# Altium schematic sheet Sheet1.SchDoc
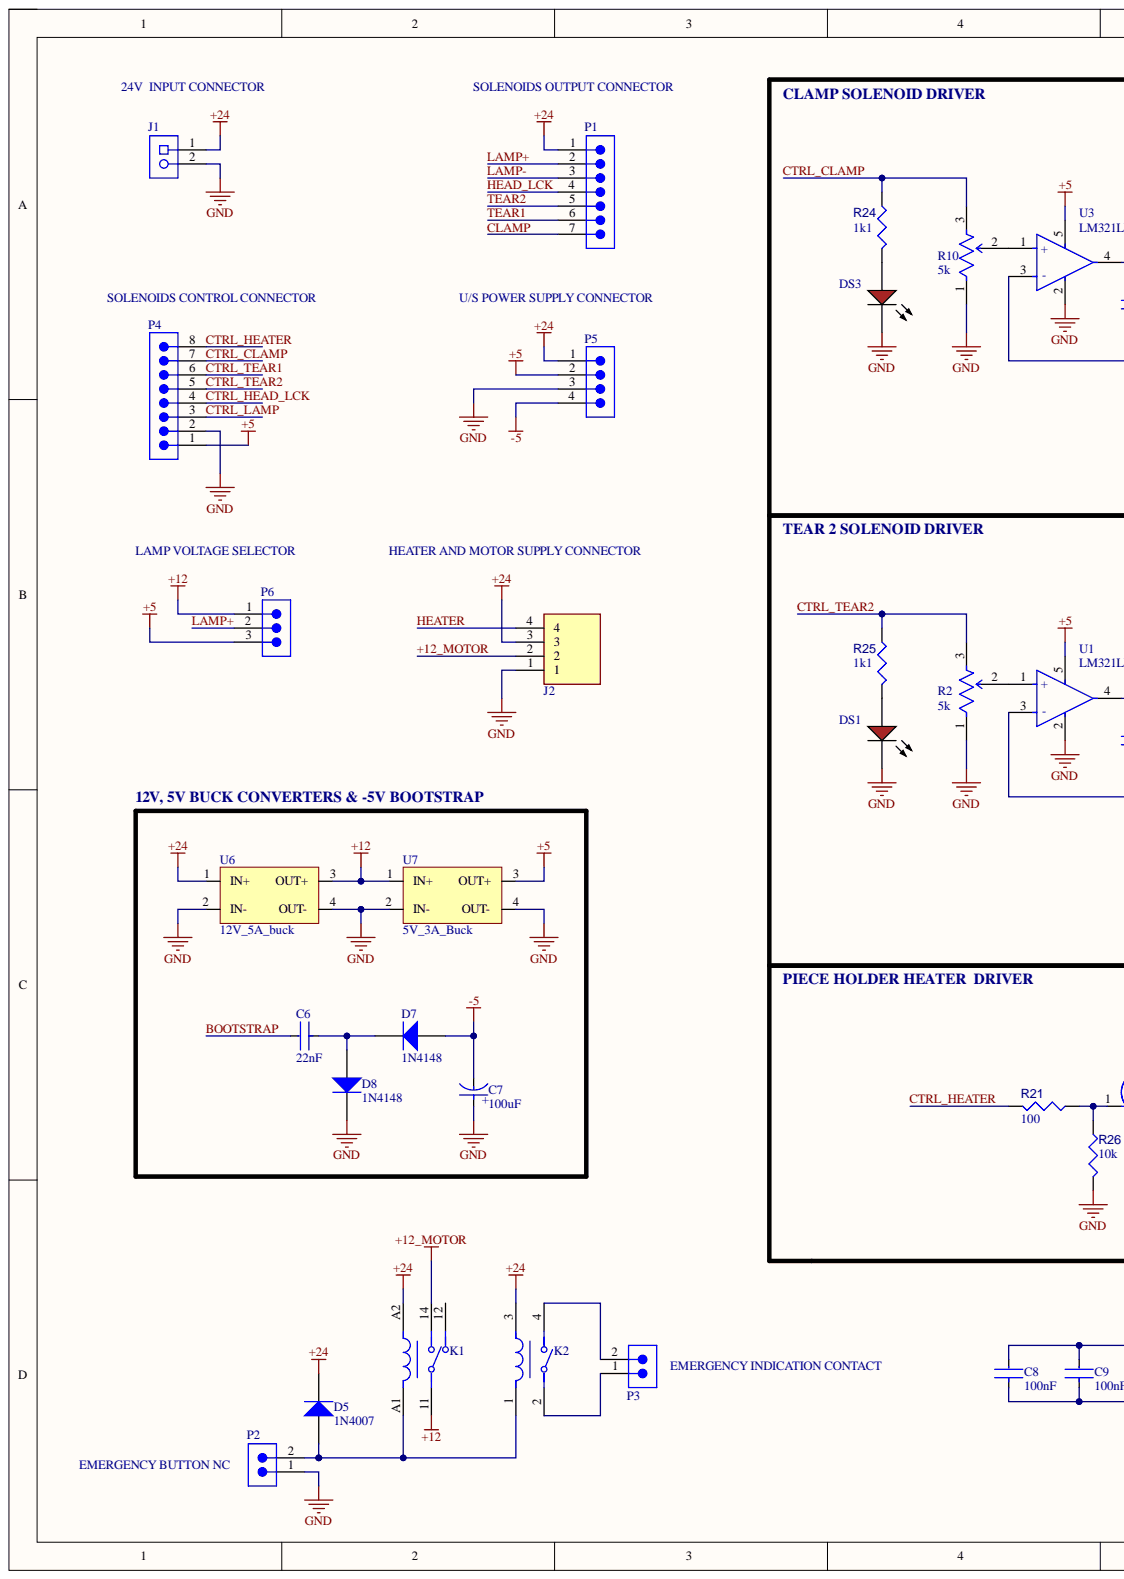
[diagram p0: Sheet1.SchDoc, left]
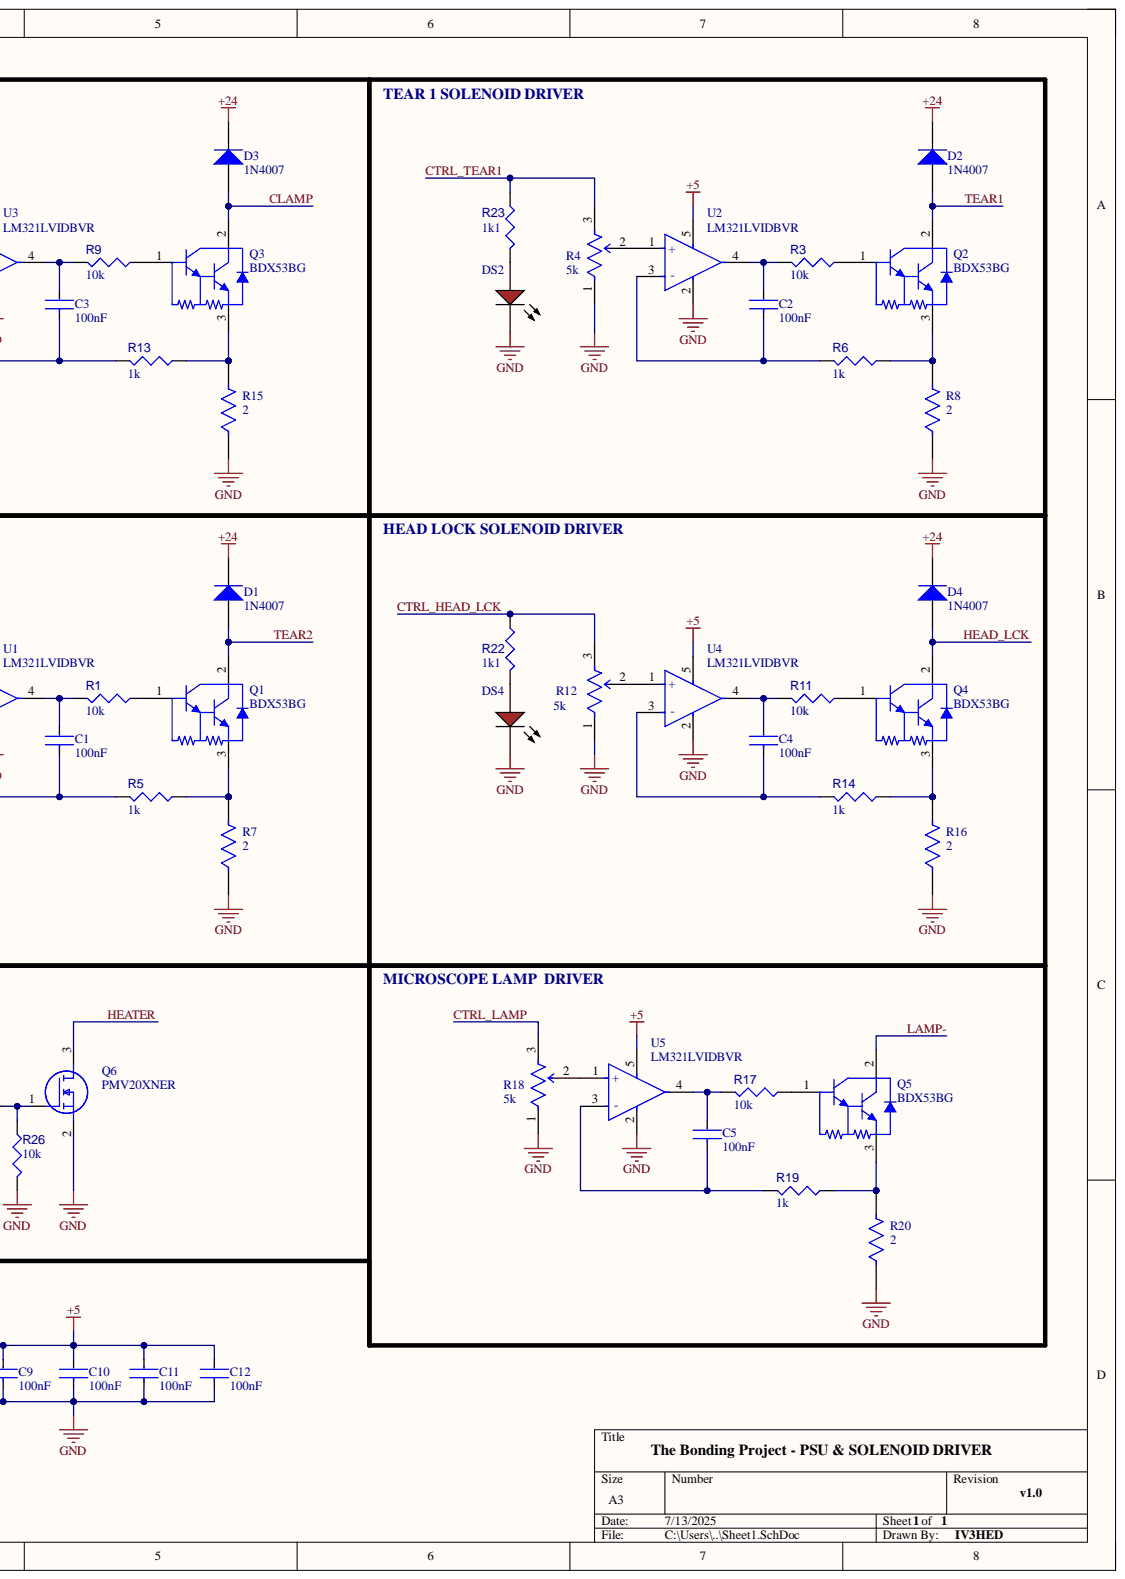
[diagram p1: Sheet1.SchDoc, right]

## Parts
C1  Capacitance: 100nF  [FP-0805-L_2_01_0_2-W_1_25-MFG]  (hidden comment: 08055C104K4T2A)
C2  Capacitance: 100nF  [FP-0805-L_2_01_0_2-W_1_25-MFG]  (hidden comment: 08055C104K4T2A)
C3  Capacitance: 100nF  [FP-0805-L_2_01_0_2-W_1_25-MFG]  (hidden comment: 08055C104K4T2A)
C4  Capacitance: 100nF  [FP-0805-L_2_01_0_2-W_1_25-MFG]  (hidden comment: 08055C104K4T2A)
C5  Capacitance: 100nF  [FP-0805-L_2_01_0_2-W_1_25-MFG]  (hidden comment: 08055C104K4T2A)
C6  Capacitance: 22nF  [FP-BFC247066332-MFG]  (hidden comment: BFC247066332)
C7  Capacitance: 100uF  [FP-RAD-TH-D_6_3_0_5-L_7_1-MFG]  (hidden comment: EEAGA1A470H)
C8  Capacitance: 100nF  [FP-0805-L_2_01_0_2-W_1_25-MFG]  (hidden comment: 08055C104K4T2A)
C9  Capacitance: 100nF  [FP-0805-L_2_01_0_2-W_1_25-MFG]  (hidden comment: 08055C104K4T2A)
C10  Capacitance: 100nF  [FP-0805-L_2_01_0_2-W_1_25-MFG]  (hidden comment: 08055C104K4T2A)
C11  Capacitance: 100nF  [FP-0805-L_2_01_0_2-W_1_25-MFG]  (hidden comment: 08055C104K4T2A)
C12  Capacitance: 100nF  [FP-0805-L_2_01_0_2-W_1_25-MFG]  (hidden comment: 08055C104K4T2A)
D1  1N4007  [NXP-SOD27-2_V]
D2  1N4007  [NXP-SOD27-2_V]
D3  1N4007  [NXP-SOD27-2_V]
D4  1N4007  [NXP-SOD27-2_V]
D5  1N4007  [NXP-SOD27-2_V]
D7  1N4148  [NXP-SOD27-2_V]
D8  1N4148  [NXP-SOD27-2_V]
DS1  LTL-1CHE  [INDPR60-254-520X380X650]  (value not drawn)
DS2  LTL-1CHE  [INDPR60-254-520X380X650]  (value not drawn)
DS3  LTL-1CHE  [INDPR60-254-520X380X650]  (value not drawn)
DS4  LTL-1CHE  [INDPR60-254-520X380X650]  (value not drawn)
J1  1729128  [PHOE-1729128-2_V]  (value not drawn)
J2  1711042  [1711042]  (value not drawn)
K1  RP011024  [FP-4-1393224-6-MFG]  (value not drawn)
K2  FEME_RST1-24  [FP-OMR-C-112H_V000-MFG]  (value not drawn)
P1  61300711121  [61300711121]  (value not drawn)
P2  61300211121  [61300211121]  (value not drawn)
P3  61300211121  [61300211121]  (value not drawn)
P4  61300811121  [61300811121]  (value not drawn)
P5  61300411121  [61300411121]  (value not drawn)
P6  61300311121  [61300311121]  (value not drawn)
Q1  BDX53BG  [ONSC-TO-220-3-221A-09]
Q2  BDX53BG  [ONSC-TO-220-3-221A-09]
Q3  BDX53BG  [ONSC-TO-220-3-221A-09]
Q4  BDX53BG  [ONSC-TO-220-3-221A-09]
Q5  BDX53BG  [ONSC-TO-220-3-221A-09]
Q6  PMV20XNER  [SOT95P230X110-3N]
R1  value 10k  [RESC0805(2012)_L]  (hidden comment: Resistor 1K +/-5% 0805 125 mW)
R2  Resistance: 5k  [FP-3296W-MFG]  (hidden comment: 3296W-1-103LF)
R3  value 10k  [RESC0805(2012)_L]  (hidden comment: Resistor 1K +/-5% 0805 125 mW)
R4  Resistance: 5k  [FP-3296W-MFG]  (hidden comment: 3296W-1-103LF)
R5  value 1k  [RESC0805(2012)_L]  (hidden comment: Resistor 1K +/-5% 0805 125 mW)
R6  value 1k  [RESC0805(2012)_L]  (hidden comment: Resistor 1K +/-5% 0805 125 mW)
R7  value 2  [FP-RL2512-MFG]  (hidden comment: CMP-03412-013102-1)
R8  value 2  [FP-RL2512-MFG]  (hidden comment: CMP-03412-013102-1)
R9  value 10k  [RESC0805(2012)_L]  (hidden comment: Resistor 1K +/-5% 0805 125 mW)
R10  Resistance: 5k  [FP-3296W-MFG]  (hidden comment: 3296W-1-103LF)
R11  value 10k  [RESC0805(2012)_L]  (hidden comment: Resistor 1K +/-5% 0805 125 mW)
R12  Resistance: 5k  [FP-3296W-MFG]  (hidden comment: 3296W-1-103LF)
R13  value 1k  [RESC0805(2012)_L]  (hidden comment: Resistor 1K +/-5% 0805 125 mW)
R14  value 1k  [RESC0805(2012)_L]  (hidden comment: Resistor 1K +/-5% 0805 125 mW)
R15  value 2  [FP-RL2512-MFG]  (hidden comment: CMP-03412-013102-1)
R16  value 2  [FP-RL2512-MFG]  (hidden comment: CMP-03412-013102-1)
R17  value 10k  [RESC0805(2012)_L]  (hidden comment: Resistor 1K +/-5% 0805 125 mW)
R18  Resistance: 5k  [FP-3296W-MFG]  (hidden comment: 3296W-1-103LF)
R19  value 1k  [RESC0805(2012)_L]  (hidden comment: Resistor 1K +/-5% 0805 125 mW)
R20  value 2  [FP-RL2512-MFG]  (hidden comment: CMP-03412-013102-1)
R21  value 100  [RESC0805(2012)_L]  (hidden comment: Resistor 1K +/-5% 0805 125 mW)
R22  value 1k1  [RESC0805(2012)_L]  (hidden comment: Resistor 1K +/-5% 0805 125 mW)
R23  value 1k1  [RESC0805(2012)_L]  (hidden comment: Resistor 1K +/-5% 0805 125 mW)
R24  value 1k1  [RESC0805(2012)_L]  (hidden comment: Resistor 1K +/-5% 0805 125 mW)
R25  value 1k1  [RESC0805(2012)_L]  (hidden comment: Resistor 1K +/-5% 0805 125 mW)
R26  value 10k  [RESC0805(2012)_L]  (hidden comment: Resistor 1K +/-5% 0805 125 mW)
U1  LM321LVIDBVR  [FP-LM321LVIDBVR-MFG]
U2  LM321LVIDBVR  [FP-LM321LVIDBVR-MFG]
U3  LM321LVIDBVR  [FP-LM321LVIDBVR-MFG]
U4  LM321LVIDBVR  [FP-LM321LVIDBVR-MFG]
U5  LM321LVIDBVR  [FP-LM321LVIDBVR-MFG]
U6  12V_5A_buck  [12V_5A_buck-Footprint-1]
U7  5V_3A_Buck  [New footprint 1]

## Nets
+5: C8.2 C9.2 C10.2 C11.2 C12.2 P4.1 P5.2 P6.3 U1.5 U2.5 U3.5 U4.5 U5.5 U7.3(OUT+)
+12: K1.11 P6.1 U6.3(OUT+) U7.1(IN+)
+24: D1.2 D2.2 D3.2 D4.2 D5.2 J1.1 J2.3 K1.A2 K2.3 P1.1 P5.1 U6.1(IN+)
-5: C7.1 D7.1 P5.4
GND: C7.2 C8.1 C9.1 C10.1 C11.1 C12.1 D8.2 DS1.2 DS2.2 DS3.2 DS4.2 J1.2 J2.1 P2.1 P4.2 P5.3 Q6.2 R2.1 R4.1 R7.1 R8.1 R10.1 R12.1 R15.1 R16.1 R18.1 R20.1 R26.2 U1.2 U2.2 U3.2 U4.2 U5.2 U6.2(IN-) U6.4(OUT-) U7.2(IN-) U7.4(OUT-)
+12_MOTOR: J2.2 K1.14
BOOTSTRAP: C6.2
CLAMP: D3.1 P1.7 Q3.2
CTRL_CLAMP: P4.7 R10.3 R24.1
CTRL_HEAD_LCK: P4.4 R12.3 R22.1
CTRL_HEATER: P4.8 R21.2
CTRL_LAMP: P4.3 R18.3
CTRL_TEAR1: P4.6 R4.3 R23.1
CTRL_TEAR2: P4.5 R2.3 R25.1
HEAD_LCK: D4.1 P1.4 Q4.2
HEATER: J2.4 Q6.3
LAMP+: P1.2 P6.2
LAMP-: P1.3 Q5.2
TEAR1: D2.1 P1.6 Q2.2
TEAR2: D1.1 P1.5 Q1.2
NetC1_1: C1.1 R5.2 U1.3(-)
NetC1_2: C1.2 R1.2 U1.4
NetC2_1: C2.1 R6.2 U2.3(-)
NetC2_2: C2.2 R3.2 U2.4
NetC3_1: C3.1 R13.2 U3.3(-)
NetC3_2: C3.2 R9.2 U3.4
NetC4_1: C4.1 R14.2 U4.3(-)
NetC4_2: C4.2 R11.2 U4.4
NetC5_1: C5.1 R19.2 U5.3(-)
NetC5_2: C5.2 R17.2 U5.4
NetC6_1: C6.1 D7.2 D8.1
NetD5_1: D5.1 K1.A1 K2.1 P2.2
NetDS1_1: DS1.1 R25.2
NetDS2_1: DS2.1 R23.2
NetDS3_1: DS3.1 R24.2
NetDS4_1: DS4.1 R22.2
NetK2_2: K2.2 P3.1
NetK2_4: K2.4 P3.2
NetQ1_1: Q1.1 R1.1
NetQ1_3: Q1.3 R5.1 R7.2
NetQ2_1: Q2.1 R3.1
NetQ2_3: Q2.3 R6.1 R8.2
NetQ3_1: Q3.1 R9.1
NetQ3_3: Q3.3 R13.1 R15.2
NetQ4_1: Q4.1 R11.1
NetQ4_3: Q4.3 R14.1 R16.2
NetQ5_1: Q5.1 R17.1
NetQ5_3: Q5.3 R19.1 R20.2
NetQ6_1: Q6.1 R21.1 R26.1
NetR2_2: R2.2 U1.1(+)
NetR4_2: R4.2 U2.1(+)
NetR10_2: R10.2 U3.1(+)
NetR12_2: R12.2 U4.1(+)
NetR18_2: R18.2 U5.1(+)

Not connected: K1 12

## Library data of the parts
C1-C5 C8-C12 = CMP-2007-04370-2: Automotive Ceramic Capacitor, 0805, 100nF, 10%, X7R, 15%, 50V; Case Code (Imperial): 0805; Case Code (Metric): 2012; Case/Package: 0805; Component Kind: Standard; Construction: Flat; Contact Plating: Tin; Depth: 1.25mm; Dielectric: X7R; Height: 0.94mm; Lead Free: Lead Free; Length: 2.01mm; Library Name: Altium Content Vault; Max Operating Temperature: 125°C; Min Operating Temperature: -55°C; Mount: Surface Mount; Number of Pins: 2; Package Quantity: 1; Packaging: Tape and Reel; Radiation Hardening: No; Ratings: AEC-Q200; REACH SVHC: No SVHC; RoHS Compliant: Yes; Series: -; Termination: SMD/SMT; Thickness: 0.037inch; Tolerance: 10%; Voltage Rating: 50V; Voltage Rating (DC): 50V; Width: 0.049inch
C6 = CMP-02419-000012-1: CAP FILM 3300PF 5% 400VDC RADIAL; Dielectric: PET; Dielectric Material: Polyester; Lead Diameter: 0.5mm; Voltage Rating: 400V; Voltage Rating (AC): 200V; Case/Package: Radial; Component Kind: Standard; Construction: RADIAL; Contact Plating: Tin; Depth: 2.5mm; Height - Seated (Max): 0.728inch; Lead Pitch: 5mm; Length: 0.283inch; Library Name: Altium Content Vault; Max Operating Temperature: 125°C; Min Operating Temperature: -40°C; Mount: Through Hole; Package Quantity: 2000; Packaging: Tape and Reel; Radiation Hardening: No; RoHS Compliant: Yes; Series: MKT470; Termination: Radial; Tolerance: 5%; Voltage Rating (DC): 400V; Width: 0.098inch
C7 = CMP-05427-001988-1: Aluminum Electrolytic Capacitors (Radial Lead Type) 47uF ±20% 10V; Leakage Current: 4.7uA; Life (Hours): 1000Hour; Ripple Current: 65mA; Case/Package: Radial; Component Kind: Standard; Diameter: 6.3mm; Features: General Purpose; Height: 7mm; Height - Seated (Max): 0.276inch; Lead Pitch: 2.5mm; Length: 7mm; Library Name: Altium Content Vault; Max Operating Temperature: 105°C; Min Operating Temperature: -55°C; Mount: Through Hole; Package Quantity: 1; Packaging: Tape and Reel; Radiation Hardening: No; RoHS Compliant: Yes; Series: GA; Termination: Radial; Tolerance: 20%; Voltage Rating: 10V; Voltage Rating (DC): 10V
D1-D5 D7 D8 = CMP-004-00000-2: High Speed Diode, 100 V, 0.45 A, -65 to 175 degC, 2-Pin SOD27, RoHS, Bulk; Manufacturer: Fairchild; Elements: 1; Forward Current: 300mA; Forward Voltage: 1V; Max Surge Current: 4A; Peak Reverse Current: 5uA; Power: 500mW; Recovery Time: 4ns; Case/Package: DO; Component Kind: Standard; Datasheet URL: http://www.nxp.com/documents/data_sheet/1N4148_1N4448.pdf; Datasheet Version: Aug-04; Diameter: 1.91mm; Manufacturer URL: https://www.fairchildsemi.com/; Max Operating Temperature: 175°C; Min Operating Temperature: -65°C; Package Quantity: 2000; Package Reference: SOD27; Package URL: http://www.nxp.com/documents/data_sheet/1N4148_1N4448.pdf; Packaging: Bulk; Pins: 2; RoHS Compliant: True; Library Name: Massimo Danelutti
DS1-DS4 = CMP-007-00018-2: LED Uni-Color Red, 100 mW, 30 mA, -55 to 100 degC, 2-Pin THD, RoHS, Bulk; Manufacturer: Lite-On; Forward Current: 20mA; Forward Voltage: 2V; Lens Style: Dome; Luminous Intensity: 12.6mcd; Viewing Angle: 60degrees; Case/Package: Radial; Colour: Red; Component Kind: Standard; Datasheet URL: https://datasheet.ciiva.com/15117/getdatasheetpartid-187746-15117985.pdf; Datasheet Version: Dec-03; Illumination Colour: Red; Manufacturer URL: http://www.us.liteon.com/; Max Operating Temperature: 100°C; Min Operating Temperature: -55°C; Mounting Technology: TH; Nominal Vf: 2V; Package Description: 2-Pin Through Hole Device, Dia 3.8 mm, Pitch 2.54 mm; Package Quantity: 1000; Package Reference: LTL-1CHE; Packaging: Bulk; Pins: 2; RoHS Compliant: True; Library Name: Massimo Danelutti
J1 = CMP-2000-05815-1: PCB Terminal Block, 13.5 A, 400 V, 2-Pin THD, RoHS, Bulk; Manufacturer: Phoenix Contact; Current Rating: 13500mA; No. of Positions: 2; No. of Rows: 1; Package Version: 03/2015; Pitch: 5.08mm; Voltage Rating: 400V; Component Kind: Standard; Datasheet URL: https://datasheet.ciiva.com/16046/1729128-16046181.pdf; Datasheet Version: 12/2013; Library Name: Altium Content Vault; Manufacturer URL: http://www.phoenixcontact.com/; Mounting Technology: ThroughHole; Orientation: Horizontal; Package Description: 2-Pin Through Hole Device, Body 8.1 x 10.16 mm, Pitch 5.08 mm; Package Reference: 1729128-2; Package URL: https://www.phoenixcontact.com/online/portal/pi/pxc/product_detail_page/!ut/p/b1/3VdJjqNKFDxLH8AFycySyWY2ozFsEPOMMQaDOX1XedGL_1Vdm5YXJleknhSKeBkvI6EA8ncAo3AYoDhBQGco6KN7VURTdemj9us_IEKEZo_YHiPAEWEFmDGOHMORkqKYCORBZxgL7ZoaZYcbFFUZ3fqxSY6GOuxydWUuHqz1ynmMFygu583Bob0Ewr6Sd_l-5m-kAueHiB0jpRwZwe58wwtkpxtMYd_LmGcDRU02dRNEyWt6Y2ti5pSyJ_reIkzIulrqApdnbT11V5jnCKbYNCvhEM1MCpPByat_X379-iThf08CI5GfOPqfBeS3BRoBOdD55AKVv0iLwCMq-2BrzXWSGRH7pUGD9uwXQjiph3xL0DKQwdGa0JImiIOmBNOestqgwTHSSs2oOep-78apyXQBvNi8ZLaFjvjWvcaUEBu0MC6Wbe-d6mB0FAebo2lUWinqYaZs5DAYzV0RP9yitUjnGJ0iI9xhZkT42OCQNUJJhkgWINNQLP2JFP6OpMg3JCVg70jqHT0lvKOnhHf0lPuOnnLf0VPuWx6_dxwUIvwk5WMkT0kLL1mh61l2yZVSzG3pYV_bqo-mj6uMKwKwdnnW8Jh5RTOJzMOA5c-eJDaZQw4mTMPH02PvS_ZAqNVjVTo2WyYLkwH_KU1mmmG5kjRhDqPXLwwVHvVOjCM60-fpXvTDfMGW1PVFVS1r4e4yVDk4ZdNbkUHvqPqQSeian4UD39fNKmachqdJ1NJbyk8rXT9mXTvPk3oE5meulKGgiruPJek-4I9hTT6G8ZLOyRSm2RRVbThERQad5OS28hdt4YW4vG0stgYYzsWsK4_7y6DZMjJSCqri1kyiZR5vD7pwIrNR7QwPHTcLTI5qT7eRlpHrdGiupYlqQDJ7zy_CND8fWWBoLRXxk1RVcXiBQwbTD4Xi7KL-FuO94t9xaqweXzH; Packing: Bulk; PartNumber: 1729128; RoHS: TRUE
J2 = 1711042: Connector; Manufacturer_Name: Phoenix Contact; Arrow Part Number: 1711042; Arrow Price/Stock: https://www.arrow.com/en/products/1711042/phoenix-contact?utm_currency=USD&region=nac; Component Kind: Standard; Datasheet Link: http://www.phoenixcontact.com/de/produkte/1711042/pdf; Height: 18.2mm; Library Name: SamacSys.SchLib; Manufacturer_Part_Number: 1711042; Mouser Part Number: 651-1711042; Mouser Price/Stock: https://www.mouser.co.uk/ProductDetail/Phoenix-Contact/1711042?qs=JcjeNNoqGSOUKx8wK4Z%252B%2Fg%3D%3D
K1 = CMP-008-00010-2: 24V Relay 10A 250V AC; Operate Time: 11.5ms; Component Kind: Standard; Max Operating Temperature: 70°C; Min Operating Temperature: -40°C; Mounting Technology: Through Hole; Pins: 5; RoHS Compliant: Yes; Library Name: Massimo Danelutti
K2 = CMP-008-00013-1: 24V relay; Component Kind: Standard; Mounting Technology: Through Hole; RoHS Compliant: Yes; Library Name: Massimo Danelutti
P1 = CMP-1502-01074-3: NexarPartId: 32855531; Connector Type: Male Pin; Contact Resistance: 20mΩ; Current Rating: 3A; Gender: Male; Number of Contacts: 7; Operating Supply Voltage: 250V; Pitch: 2.54mm; Color: Black; Component Kind: Standard; Contact Material: Copper; Contact Mating Length: 5.9944mm; Contact Plating: Gold; Lead Pitch: 2.54mm; Library Name: Altium Content Vault; Mount: Through Hole; Number of Pins: 7; Number of Positions: 7; Number of Rows: 1; Orientation: Vertical; Packaging: Bulk; REACH SVHC: Unknown; RoHS: Compliant; Termination: Solder
P2 P3 = CMP-1712-00002-6: NexarPartId: 20081794; Connector Type: Male Pin; Contact Resistance: 20mΩ; Current Rating: 3A; Gender: Male; Number of Contacts: 2; Operating Supply Voltage: 250V; Pitch: 2.54mm; Color: Black; Component Kind: Standard; Contact Gender: Male; Contact Material: Copper; Contact Mating Length: 5.9944mm; Contact Plating: Gold; Height: 8.94mm; Housing Material: PBT; Lead Free: Lead Free; Lead Pitch: 2.54mm; Library Name: Altium Content Vault; Max Operating Temperature: 125°C; Min Operating Temperature: -40°C; Mount: Through Hole; Number of Pins: 2; Number of Positions: 2; Number of Rows: 1; Orientation: Vertical; Packaging: Bulk; REACH SVHC: No SVHC; RoHS: Compliant; Termination: Through Hole; Voltage Rating: 250V
P4 = CMP-1502-01076-3: NexarPartId: 32855533; Connector Type: Male Pin; Contact Resistance: 20mΩ; Current Rating: 3A; Gender: Male; Number of Contacts: 8; Operating Supply Voltage: 250V; Pitch: 2.54mm; Color: Black; Component Kind: Standard; Contact Material: Copper; Contact Mating Length: 5.9944mm; Contact Plating: Gold; Height: 8.54mm; Lead Pitch: 2.54mm; Library Name: Altium Content Vault; Max Operating Temperature: 105°C; Min Operating Temperature: -40°C; Mount: Through Hole; Number of Pins: 8; Number of Positions: 8; Number of Rows: 1; Orientation: Vertical; Packaging: Bulk; REACH SVHC: Unknown; RoHS: Compliant; Termination: Solder; Voltage Rating: 250V
P5 = CMP-1502-01064-3: NexarPartId: 32855522; Connector Type: Male Pin; Contact Resistance: 20mΩ; Current Rating: 3A; Gender: Male; Number of Contacts: 4; Operating Supply Voltage: 250V; Pitch: 2.54mm; Color: Black; Component Kind: Standard; Contact Material: Copper; Contact Mating Length: 5.9944mm; Contact Plating: Gold; Height: 8.89mm; Lead Free: Lead Free; Lead Pitch: 2.54mm; Library Name: Altium Content Vault; Max Operating Temperature: 105°C; Min Operating Temperature: -40°C; Mount: Through Hole; Number of Pins: 4; Number of Positions: 4; Number of Rows: 1; Orientation: Vertical; Packaging: Bulk; REACH SVHC: Unknown; RoHS: Compliant; Termination: Solder; Voltage Rating: 250V
P6 = CMP-1502-01062-3: NexarPartId: 32855521; Connector Type: Male Pin; Contact Resistance: 20mΩ; Current Rating: 3A; Gender: Male; Number of Contacts: 3; Operating Supply Voltage: 250V; Pitch: 2.54mm; Color: Black; Component Kind: Standard; Contact Material: Copper; Contact Mating Length: 5.9944mm; Contact Plating: Gold; Height: 8.94mm; Lead Free: Lead Free; Lead Pitch: 2.54mm; Library Name: Altium Content Vault; Max Operating Temperature: 105°C; Min Operating Temperature: -40°C; Mount: Through Hole; Number of Pins: 3; Number of Positions: 3; Number of Rows: 1; Orientation: Vertical; Packaging: Bulk; REACH SVHC: Unknown; RoHS: Compliant; Termination: Solder; Voltage Rating: 250V
Q1-Q5 = CMP-1047-00095-1: Plastic Medium-Power Complementary Silicon Transistor, 3-Pin TO-220, Pb-Free, Tube; Manufacturer: On Semiconductor; IC Continuous (A): 8; Polarity: NPN; Component Kind: Standard; ComponentLink1Description: Manufacturer URL; ComponentLink1URL: http://www.onsemi.com/; ComponentLink2Description: Datasheet; ComponentLink2URL: http://www.onsemi.com/pub_link/Collateral/BDX53B-D.PDF; ComponentLink3Description: Package Specification; ComponentLink3URL: http://www.onsemi.com/pub_link/Collateral/221A-09.PDF; DatasheetVersion: Rev. 14; Library Name: Altium Content Vault; Mounting Technology: Through Hole; PackageDescription: 3-Pin Flange Mount, Vertical Straight Lead, Body 9.97 x 4.445 mm, Pitch 2.54 mm; PackageReference: TO-220-3-221A-09; PackageVersion: Rev. AG, 09/2011; Packing: Tube; PartNumber: BDX53BG; RoHS: TRUE
Q6 = PMV20XNER: MOSFET (N-Channel); Manufacturer_Name: Nexperia; Arrow Part Number: PMV20XNER; Arrow Price/Stock: https://www.arrow.com/en/products/pmv20xner/nexperia?region=nac; Component Kind: Standard; Datasheet Link: https://assets.nexperia.com/documents/data-sheet/PMV20XNE.pdf; Height: 1.1mm; Manufacturer_Part_Number: PMV20XNER; Mouser Part Number: 771-PMV20XNER; Mouser Price/Stock: https://www.mouser.co.uk/ProductDetail/Nexperia/PMV20XNER?qs=%252BRKXB2WKlSevPnXiP0DAaQ%3D%3D
R1 R3 R5 R6 R9 R11 R13 R14 R17 R19 R21-R26 = CMP-009-00118-3: Chip Resistor, 1 kOhms, +/-5%, 125 mW, -55 to 155 degC, 0805; Power: 125mW; Tolerance: 5%; Case/Package: 0805; Component Kind: Standard; Max Operating Temperature: 155°C; Min Operating Temperature: -55°C; Pins: 2; Library Name: Massimo Danelutti
R2 R4 R10 R12 R18 = CMP-1747-00001-8: TRIMMER 10K OHM 0.5W PC PIN TOP; Insulation Resistance: 1GR; Max Power Dissipation: 500mW; Number of Turns: 25; Resistance Tolerance: 10%; Temperature Coefficient: 100ppm/°C; Color: Blue; Component Kind: Standard; Contact Plating: Tin; Depth: 4.83mm; Height: 11.55mm; Lead Free: Lead Free; Length: 9.53mm; Library Name: Altium Content Vault; Max Operating Temperature: 125°C; Military Standard: Not; Min Operating Temperature: -55°C; Mount: Through Hole; Package Quantity: 50; Packaging: Rail/Tube; Power Rating: 500mW; Radiation Hardening: No; Reach SVHC Compliant: Yes; Resistor Type: Cermet; RoHS Compliant: Yes; Series: 3296; Taper: Linear; Technology: CERMET; Termination: Pin; Tolerance: 10%; Weight: 0.03oz; Width: 9.53mm
R7 R8 R15 R16 R20 = CMP-009-00181-1: RES 0.1 OHM 1% 1W 2512; Manufacturer: YAGEO; Manufacturer Part Number: RL2512FK-070R1L; Automotive Grade: Grade 0; Temperature Coefficient: 600ppm/°C; Automotive: Yes; Case/Package: RL2512; Category: Res; Component Kind: Standard; Component Type: Standard; Composition: Thick Film; Design Item ID: CMP-03412-013102-1; Device Class L1: Passive Components; Device Class L2: Resistors; Device Class L3: Chip SMD Resistors; Digikey Description: RES 0.1 OHM 1% 1W 2512; Footprint Url: http://www.yageo.com/exep/pages/download/literatures/PYu-R_Mount_10.pdf; Height: 0.65mm; Ipc Land Pattern Name: RESC635320X55; Lead Free: Yes; Mouser Description: Current Sense Resistors 0.1ohm 1% 1W; Octopart: https://octopart.com/rl2512fk-070r1l-yageo-42334369; Pins: 2; Power Rating: 1W; RoHS: TRUE; Series: RL; Source: Components - 01.05.25 - 15.35.SchLib; Temperature: -55°C to +155°C; Temperature Range High: 155°C; Temperature Range Low: -55°C; Tolerance: 1%; Library Name: Massimo Danelutti
U1-U5 = CMP-04895-000016-1: IC OPAMP GP 1 CIRCUIT SOT23-5; Manufacturer: Texas Instruments; Gain Bandwidth: 1MHz; Input Bias Current: 0.000015uA; Input Offset Voltage: 1mV; Max Dual Supply Voltage: 2.75V; Max Junction Temp: +150°C; Max Supply Voltage: 5.5V; Min Dual Supply Voltage: 1.35V; Min Supply Voltage: 2.7V; Nominal Supply Current: 90uA; Number Of Channels: 1; Output Current Per Channel: 40mA; Response Time: 5us; Standoff Height: 0mm; Voltage Gain: 125dB; Ambient Temperature Range High: +125°C; Ambient Temperature Range Low: -40°C; Automotive: No; Category: IC; Component Kind: Standard; ComponentLink2Description: Datasheet; ComponentLink2URL: http://www.ti.com/lit/ds/symlink/lm321lv.pdf; Device Class L1: Integrated Circuits (ICs); Device Class L2: Linear ICs; Device Class L3: Amplifiers - Op Amps; Digikey Description: IC OPAMP GP 1 CIRCUIT SOT23-5; Height: 1.45mm; Lead Free: Yes; Library Name: Altium Content Vault; Manufacturer Part Number: LM321LVIDBVR; Mouser Description: 1-Channel industry standard low voltage operational amplifier 5-SOT-23 -40 to 125; Octopart: https://octopart.com/lm321lvidbvr-texas+instruments-95825365; Package: SOT-23-5; RoHS: TRUE; Temperature: -40°C to +125°C; Temperature Range High: +125°C; Temperature Range Low: -40°C
U6 = CMP-019-00050-3: 12V 5A Buck Converter; Component Kind: Standard; Library Name: Massimo Danelutti
U7 = CMP-019-00051-1: 5V 3A buck converter; Component Kind: Standard; Library Name: Massimo Danelutti
